# Altium schematic sheet [10] - DIGITAL INPUT.SchDoc("[10] - DIGITAL INPUT")
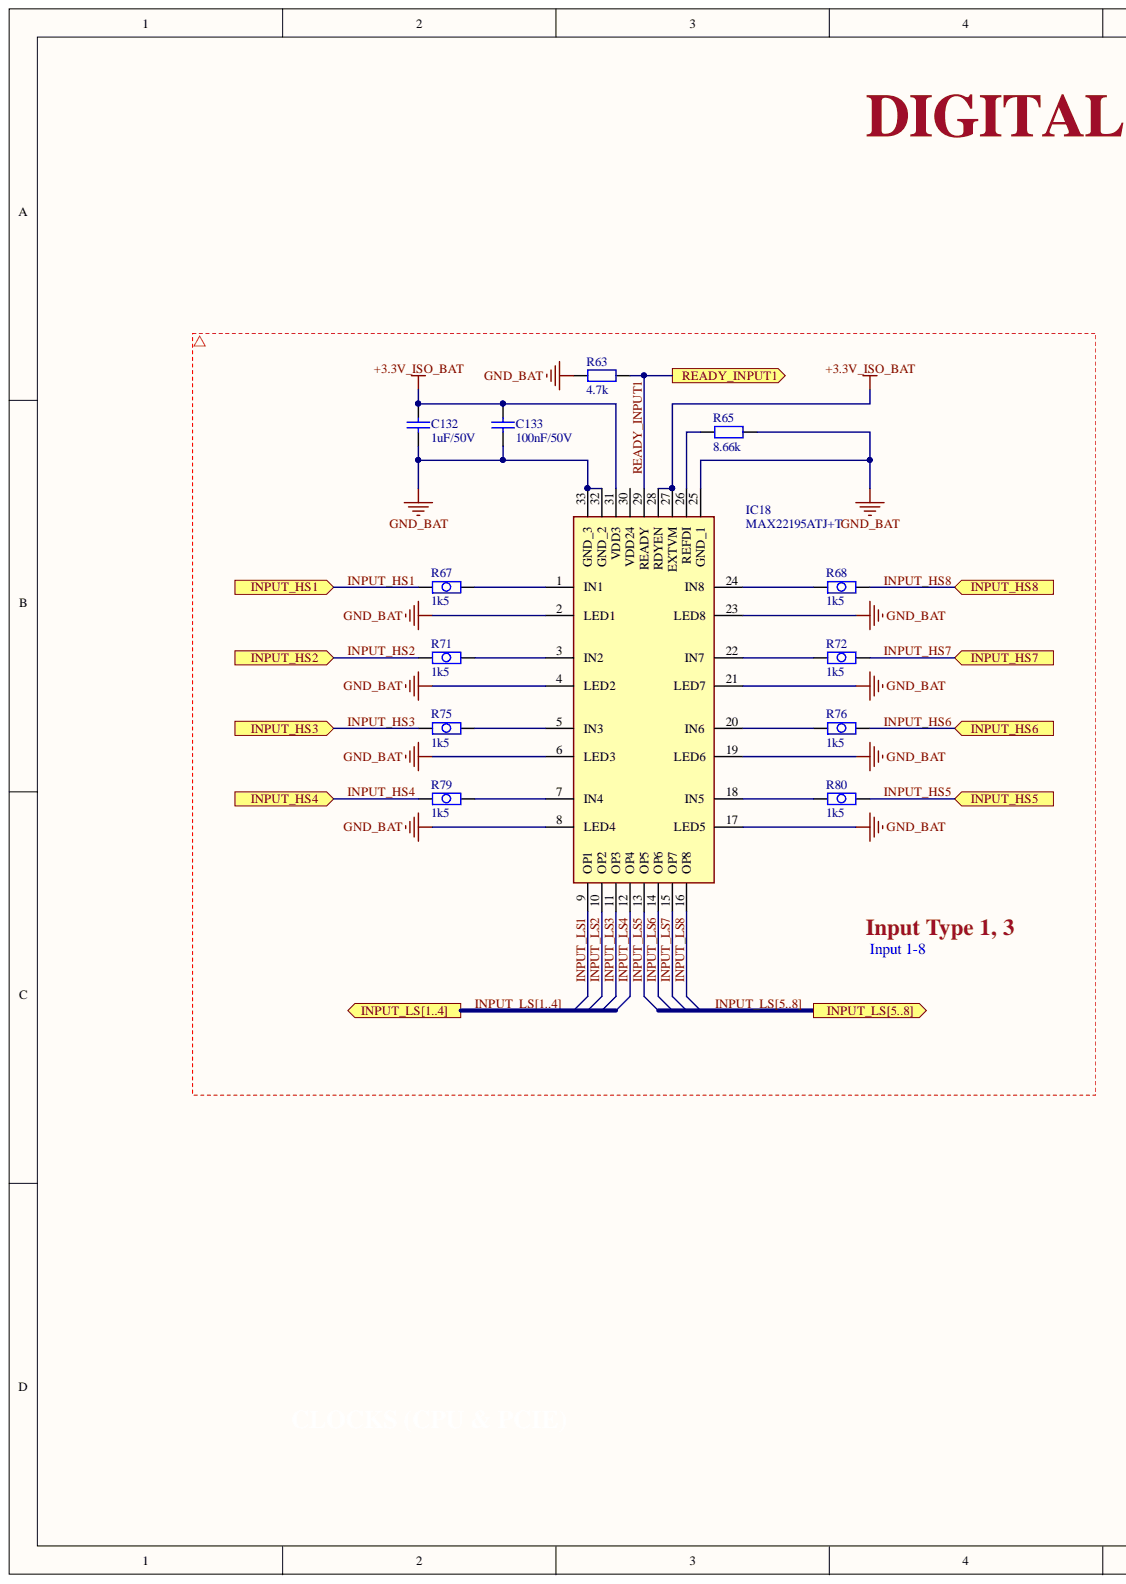
[diagram p0: [10] - DIGITAL INPUT.SchDoc, left]
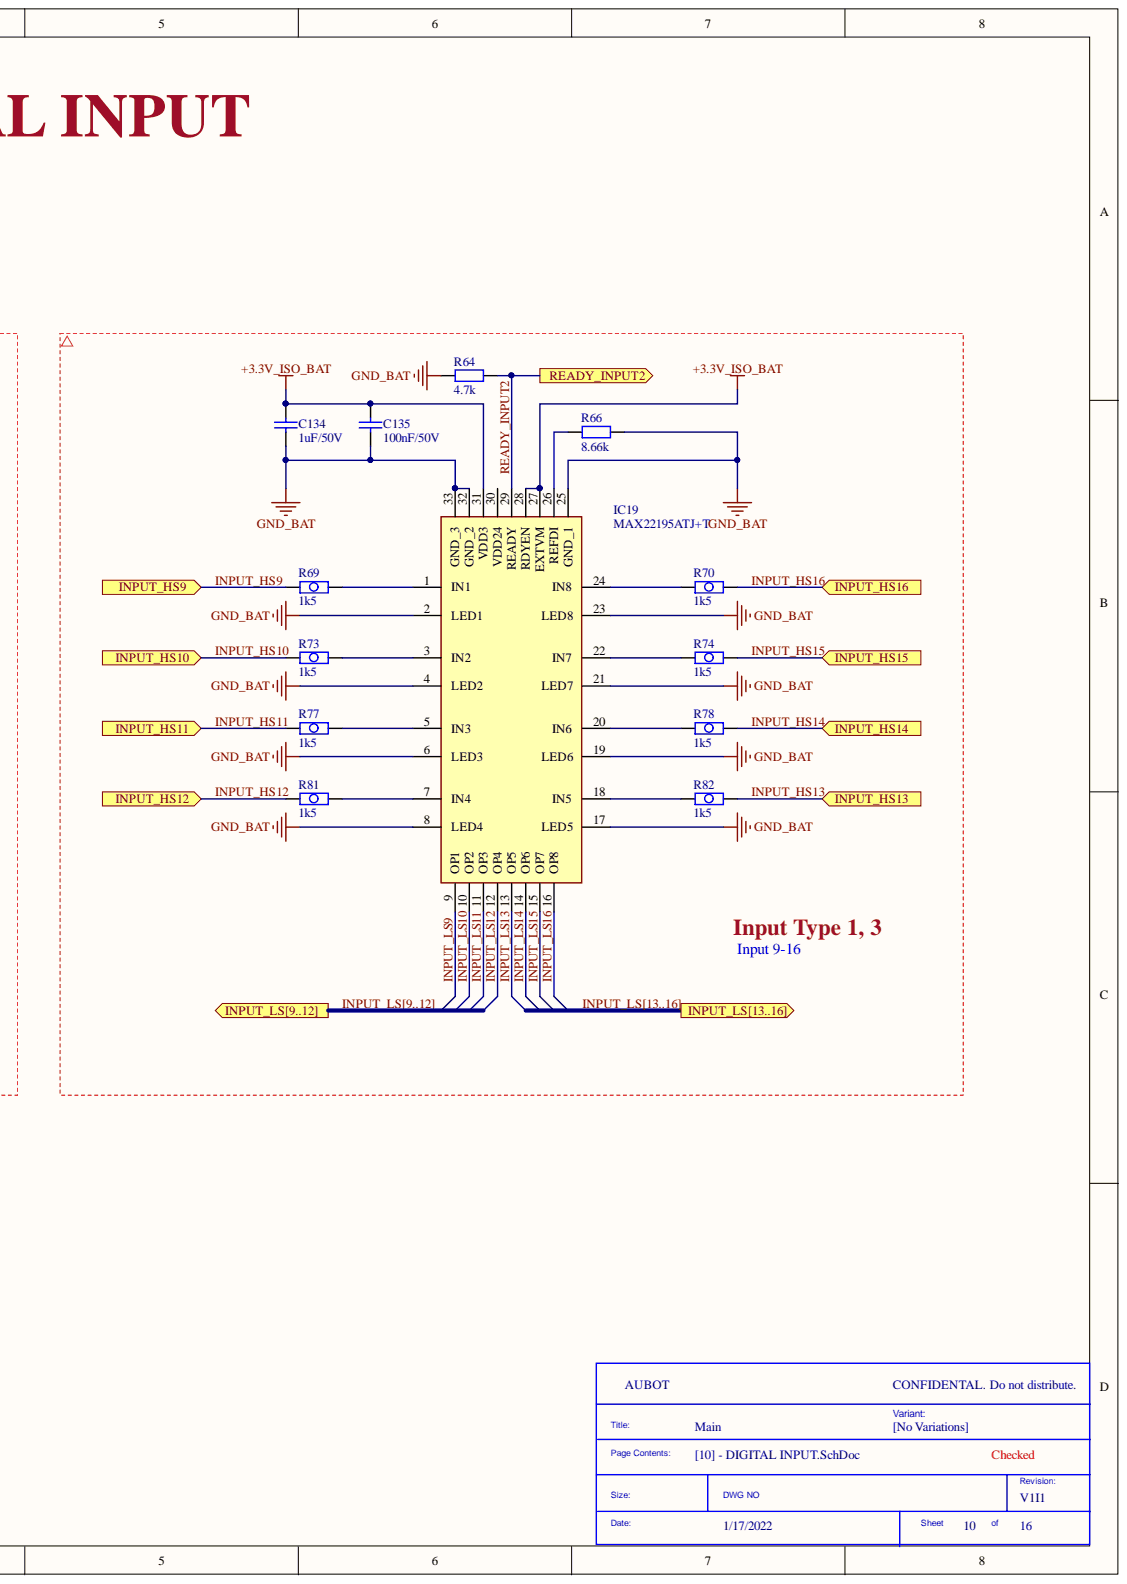
[diagram p1: [10] - DIGITAL INPUT.SchDoc, right]

## Parts
C132  1uF/50V  [CAP 0805]
C133  100nF/50V  [CAP 0805]
C134  1uF/50V  [CAP 0805]
C135  100nF/50V  [CAP 0805]
IC18  MAX22195ATJ+T  [32-WFQFN Exposed Pad]
IC19  MAX22195ATJ+T  [32-WFQFN Exposed Pad]
R63  4.7k  [RES 0805]
R64  4.7k  [RES 0805]
R65  8.66k  [RES 0805]
R66  8.66k  [RES 0805]
R67  1k5  [CMB 0207]
R68  1k5  [CMB 0207]
R69  1k5  [CMB 0207]
R70  1k5  [CMB 0207]
R71  1k5  [CMB 0207]
R72  1k5  [CMB 0207]
R73  1k5  [CMB 0207]
R74  1k5  [CMB 0207]
R75  1k5  [CMB 0207]
R76  1k5  [CMB 0207]
R77  1k5  [CMB 0207]
R78  1k5  [CMB 0207]
R79  1k5  [CMB 0207]
R80  1k5  [CMB 0207]
R81  1k5  [CMB 0207]
R82  1k5  [CMB 0207]

## Ports
INPUT_HS1, INPUT_HS2, INPUT_HS3, INPUT_HS4, INPUT_HS5, INPUT_HS6, INPUT_HS7, INPUT_HS8, INPUT_HS9, INPUT_HS10, INPUT_HS11, INPUT_HS12, INPUT_HS13, INPUT_HS14, INPUT_HS15, INPUT_HS16, INPUT_LS1, INPUT_LS2, INPUT_LS3, INPUT_LS4, INPUT_LS5, INPUT_LS6, INPUT_LS7, INPUT_LS8, INPUT_LS9, INPUT_LS10, INPUT_LS11, INPUT_LS12, INPUT_LS13, INPUT_LS14, INPUT_LS15, INPUT_LS16, INPUT_LS[1..4], INPUT_LS[5..8], INPUT_LS[9..12], INPUT_LS[13..16], READY_INPUT1, READY_INPUT2

## Nets
+3.3V_ISO_BAT: C132.2 C133.2 C134.2 C135.2 IC18.27(EXTVM) IC18.28(RDYEN) IC18.31(VDD3) IC19.27(EXTVM) IC19.28(RDYEN) IC19.31(VDD3)
GND_BAT: C132.1 C133.1 C134.1 C135.1 IC18.2(LED1) IC18.4(LED2) IC18.6(LED3) IC18.8(LED4) IC18.17(LED5) IC18.19(LED6) IC18.21(LED7) IC18.23(LED8) IC18.25(GND_1) IC18.32(GND_2) IC18.33(GND_3) IC19.2(LED1) IC19.4(LED2) IC19.6(LED3) IC19.8(LED4) IC19.17(LED5) IC19.19(LED6) IC19.21(LED7) IC19.23(LED8) IC19.25(GND_1) IC19.32(GND_2) IC19.33(GND_3) R63.1 R64.1 R65.2 R66.2
INPUT_HS1: R67.1 ; port INPUT_HS1
INPUT_HS2: R71.1 ; port INPUT_HS2
INPUT_HS3: R75.1 ; port INPUT_HS3
INPUT_HS4: R79.1 ; port INPUT_HS4
INPUT_HS5: R80.2 ; port INPUT_HS5
INPUT_HS6: R76.2 ; port INPUT_HS6
INPUT_HS7: R72.2 ; port INPUT_HS7
INPUT_HS8: R68.2 ; port INPUT_HS8
INPUT_HS9: R69.1 ; port INPUT_HS9
INPUT_HS10: R73.1 ; port INPUT_HS10
INPUT_HS11: R77.1 ; port INPUT_HS11
INPUT_HS12: R81.1 ; port INPUT_HS12
INPUT_HS13: R82.2 ; port INPUT_HS13
INPUT_HS14: R78.2 ; port INPUT_HS14
INPUT_HS15: R74.2 ; port INPUT_HS15
INPUT_HS16: R70.2 ; port INPUT_HS16
INPUT_LS1: IC18.9(OP1) ; port INPUT_LS[1..4]  [also labelled INPUT_LS]
INPUT_LS2: IC18.10(OP2) ; port INPUT_LS[1..4]  [also labelled INPUT_LS]
INPUT_LS3: IC18.11(OP3) ; port INPUT_LS[1..4]  [also labelled INPUT_LS]
INPUT_LS4: IC18.12(OP4) ; port INPUT_LS[1..4]  [also labelled INPUT_LS]
INPUT_LS5: IC18.13(OP5) ; port INPUT_LS[5..8]  [also labelled INPUT_LS]
INPUT_LS6: IC18.14(OP6) ; port INPUT_LS[5..8]  [also labelled INPUT_LS]
INPUT_LS7: IC18.15(OP7) ; port INPUT_LS[5..8]  [also labelled INPUT_LS]
INPUT_LS8: IC18.16(OP8) ; port INPUT_LS[5..8]  [also labelled INPUT_LS]
INPUT_LS9: IC19.9(OP1) ; port INPUT_LS[9..12]  [also labelled INPUT_LS]
INPUT_LS10: IC19.10(OP2) ; port INPUT_LS[9..12]  [also labelled INPUT_LS]
INPUT_LS11: IC19.11(OP3) ; port INPUT_LS[9..12]  [also labelled INPUT_LS]
INPUT_LS12: IC19.12(OP4) ; port INPUT_LS[9..12]  [also labelled INPUT_LS]
INPUT_LS13: IC19.13(OP5) ; port INPUT_LS[13..16]  [also labelled INPUT_LS]
INPUT_LS14: IC19.14(OP6) ; port INPUT_LS[13..16]  [also labelled INPUT_LS]
INPUT_LS15: IC19.15(OP7) ; port INPUT_LS[13..16]  [also labelled INPUT_LS]
INPUT_LS16: IC19.16(OP8) ; port INPUT_LS[13..16]  [also labelled INPUT_LS]
READY_INPUT1: IC18.29(READY) R63.2 ; port READY_INPUT1
READY_INPUT2: IC19.29(READY) R64.2 ; port READY_INPUT2
NetIC18_1: IC18.1(IN1) R67.2
NetIC18_3: IC18.3(IN2) R71.2
NetIC18_5: IC18.5(IN3) R75.2
NetIC18_7: IC18.7(IN4) R79.2
NetIC18_18: IC18.18(IN5) R80.1
NetIC18_20: IC18.20(IN6) R76.1
NetIC18_22: IC18.22(IN7) R72.1
NetIC18_24: IC18.24(IN8) R68.1
NetIC18_26: IC18.26(REFDI) R65.1
NetIC19_1: IC19.1(IN1) R69.2
NetIC19_3: IC19.3(IN2) R73.2
NetIC19_5: IC19.5(IN3) R77.2
NetIC19_7: IC19.7(IN4) R81.2
NetIC19_18: IC19.18(IN5) R82.1
NetIC19_20: IC19.20(IN6) R78.1
NetIC19_22: IC19.22(IN7) R74.1
NetIC19_24: IC19.24(IN8) R70.1
NetIC19_26: IC19.26(REFDI) R66.1

Not connected: IC18 30(VDD24); IC19 30(VDD24)

## Library data of the parts
C132 C134 = Cap 0805 1uF/50V: 0805 1 uF 50 V ±10 % Tolerance X7R SMT Multilayer Ceramic Capacitor; Manufacturer Part Number: CC0805KKX7R9BB105; Manufacturer: Yageo; Voltage Rating (DC): 50V; Tolerance: 10%; Capacitance: 1uF; Width: 0.049inch; Weight: 0.000194oz; Voltage Rating: 50V; Thickness: 0.057inch; Termination: SMD/SMT; Supplier Part Number 1: 311-1886-1-ND; Supplier 1: Digi-Key; Stock 1: 174238; Series: CC; RoHS Compliant: Yes; RoHS: Yes; Resistor Type: Ceramic; Radiation Hardening: No; Pricing 1: 1 = 0.26000, 10 = 0.17900, 50 = 0.11920, 100 = 0.10130, 500 = 0.07152, 1000 = 0.06257 (USD); Packaging: Tape and Reel; Mount: Surface Mount; Min Operating Temperature: -55°C; Max Operating Temperature: 125°C; Length: 2mm; Lead Free: Lead Free; Height: 1.25mm; Halogen Free: Halogen Free; Dielectric: X7R; Depth: 1.25mm; Construction: Flat; ComponentLink1URL: https://datasheet.ciiva.com/13209/upy-gphc-x7r-6-3v-to-50v-8-12304-13209375.pdf?src-supplier=Mouser; ComponentLink1Description: https://datasheet.ciiva.com/13209/upy-gphc-x7r-6-3v-to-50v-8-12304-13209375.pdf?src-supplier=Mouser; Component Kind: Standard; Case Code (Metric): 2012; Case Code (Imperial): 0805; Case/Package: 0805; Library Name: Hieu_Lib.IntLib
C133 C135 = Cap 0805 100nF/50V: Ceramic capacitor SMD-0805 100nF /50V X7R# K +-10%, Samsung, RoHS; Manufacturer Part Number: CL21B104KBCNNNC; Voltage Rating (DC): 50V; Tolerance: 10%; Dielectric: X7R; Width: 0.049inch; Voltage Rating: 50V; Voltage: 50V; Thickness: 0.037inch; Supplier Part Number 1: C1711; Supplier 1: LCSC; Stock 1: 447650; Series: CL; RoHS Compliant: Yes; RoHS: Yes; Radiation Hardening: No; Pricing 1: 50 = 0.04090, 500 = 0.03130, 1500 = 0.02890, 5000 = 0.02580, 25000 = 0.02430, 50000 = 0.02410, 50 = 0.03950, 500 = 0.03020, 1500 = 0.02790, 5000 = 0.02490, 25000 = 0.02350, 50000 = 0.02330, 50 = 0.20680, 500 = 0.15820, 1500 = 0.14600, 5000 = 0.13020, 25000 = 0.12290, 50000 = 0.12170, 50 = 0.19640, 500 = 0.15020, 1500 = 0.13860, 5000 = 0.12360, 25000 = 0.11670, 50000 = 0.11550, 50 = 0.02640, 500 = 0.02020, 1500 = 0.01860, 5000 = 0.01660, 25000 = 0.01570, 50000 = 0.01550, 50 = 0.02300, 500 = 0.01760, 1500 = 0.01620, 5000 = 0.01440, 25000 = 0.01360, 50000 = 0.01350, 50 = 0.24740, 500 = 0.18920, 1500 = 0.17470, 5000 = 0.15570, 25000 = 0.14700, 50000 = 0.14560, 50 = 3.45220, 500 = 2.64000, 1500 = 2.43700, 5000 = 2.17300, 25000 = 2.05110, 50000 = 2.03080, 50 = 0.13070, 500 = 0.09990, 1500 = 0.09220, 5000 = 0.08230, 25000 = 0.07760, 50000 = 0.07690, 50 = 2.39050, 500 = 1.82810, 1500 = 1.68750, 5000 = 1.50470, 25000 = 1.42030, 50000 = 1.40620, 50 = 0.26740, 500 = 0.20450, 1500 = 0.18870, 5000 = 0.16830, 25000 = 0.15890, 50000 = 0.15730, 50 = 0.04230, 500 = 0.03230, 1500 = 0.02990, 5000 = 0.02660, 25000 = 0.02510, 50000 = 0.02490, 50 = 0.03188, 500 = 0.02438, 1500 = 0.02251, 5000 = 0.02007, 25000 = 0.01894, 50000 = 0.01875 (AUD); Packaging: Tape and Reel; Mount: Surface Mount; Min Operating Temperature: -55°C; Max Operating Temperature: 125°C; Material: Ceramic; Manufacturer: Samsung; Length: 2mm; Lead Free: Lead Free; Height: 0.85mm; Depth: 1.25mm; Contact Plating: Tin; Construction: Flat; ComponentLink2URL: https://datasheet.ciiva.com/29199/454152-da-01-en-vs-kondensator-0805-29199814.pdf?src-supplier=Conrad; ComponentLink2Description: https://datasheet.ciiva.com/29199/454152-da-01-en-vs-kondensator-0805-29199814.pdf?src-supplier=Conrad; ComponentLink1URL: https://datasheet.ciiva.com/25710/cl21b104kbcnnnc-25710182.pdf?src-supplier=Digi-Key; ComponentLink1Description: https://datasheet.ciiva.com/25710/cl21b104kbcnnnc-25710182.pdf?src-supplier=Digi-Key; Component Kind: Standard; Case Code (Metric): 2012; Case Code (Imperial): 0805; Case/Package: 0805; Capacitance: 100nF; Library Name: Hieu_Lib.IntLib
IC18 IC19 = MAX22195ATJ+T: Industry's Smallest, Robust, Octal Digital Input with Parallel Output; Manufacturer: Maxim; Supplier Part Number 1: MAX22195ATJ+T-ND; Supplier 1: Digi-Key; Stock 1: 0; RoHS: No; Pricing 1: 2500 = 3.24000 (USD); Manufacturer Part Number: MAX22195ATJ+T; ComponentLink1URL: https://datasheets.maximintegrated.com/en/ds/MAX22195.pdf?src-supplier=Digi-Key; ComponentLink1Description: https://datasheets.maximintegrated.com/en/ds/MAX22195.pdf?src-supplier=Digi-Key; Component Kind: Standard; Library Name: Hieu_Lib.SchLib
R63 R64 = Res 0805 4.7k Ohm 1%: General Purpose Chip Resistor Thick Film 0805 4.7kOhm 1% Paper T/R; Manufacturer Part Number: RC0805FR-074K7L; Manufacturer: Yageo; Voltage Rating (DC): 150V; Temperature Coefficient: 100ppm/°C; Resistance: 4.7kR; Power Rating: 125mW; Width: 0.049inch; Voltage Rating: 150V; Tolerance: 1%; Termination: SMD/SMT; Technology: THICK FILM; Supplier Part Number 1: 311-4.70KCRCT-ND; Supplier 1: Digi-Key; Stock 1: 159494; Size Code: 0805; Series: RC; RoHS Compliant: Yes; RoHS: Yes; Resistor Type: Thick Film; Resistance Tolerance: 1%; REACH SVHC: No SVHC; Pricing 1: 1 = 0.10000, 10 = 0.04200, 100 = 0.01690, 1000 = 0.00759, 2500 = 0.00659 (USD); Packaging: Tape and Reel; Package Quantity: 5000; Number of Terminations: 2; Number of Pins: 2; Min Operating Temperature: -55°C; Military Standard: Not; Max Power Dissipation: 125mW; Max Operating Temperature: 155°C; Length: 0.079inch; Lead Free: Lead Free; Height: 0.024inch; Features: Moisture Resistant; Depth: 1.25mm; Construction: Rectangular; ComponentLink6URL: https://datasheet.ciiva.com/46447/444164-46447583.pdf?src-supplier=Element14; ComponentLink6Description: https://datasheet.ciiva.com/46447/444164-46447583.pdf?src-supplier=Element14; ComponentLink5URL: https://datasheet.ciiva.com/6547/pyu-r-int-thick-7-6547263.pdf?src-supplier=Digi-Key; ComponentLink5Description: https://datasheet.ciiva.com/6547/pyu-r-int-thick-7-6547263.pdf?src-supplier=Digi-Key; ComponentLink4URL: https://datasheet.ciiva.com/7941/444164-7941806.pdf?src-supplier=Element14; ComponentLink4Description: https://datasheet.ciiva.com/7941/444164-7941806.pdf?src-supplier=Element14; ComponentLink3URL: https://datasheet.ciiva.com/7941/1686128-7941805.pdf?src-supplier=Element14; ComponentLink3Description: https://datasheet.ciiva.com/7941/1686128-7941805.pdf?src-supplier=Element14; ComponentLink2URL: https://datasheet.ciiva.com/7941/444164-7941804.pdf?src-supplier=Element14; ComponentLink2Description: https://datasheet.ciiva.com/7941/444164-7941804.pdf?src-supplier=Element14; ComponentLink1URL: https://datasheet.ciiva.com/11808/0900766b8106beab-11808155.pdf?src-supplier=RSComponents; ComponentLink1Description: https://datasheet.ciiva.com/11808/0900766b8106beab-11808155.pdf?src-supplier=RSComponents; Component Kind: Standard; Case Code (Metric): 2012; Case Code (Imperial): 0805; Case/Package: 0805; Library Name: Hieu_Lib.SchLib
R65 R66 = Res 0805 8.66k Ohm 1%: Res Thick Film 2.0 x 1.2 mm 8.66K Ohm 1% 0.125W(1/8W) 100ppm/ C Molded SMD Paper T/R; Manufacturer Part Number: RC0805FR-078K66L; Manufacturer: Yageo; Voltage Rating (DC): 150V; Temperature Coefficient: 100ppm/°C; Resistance: 8.66kR; Power Rating: 125mW; Width: 0.049inch; Voltage Rating: 150V; Tolerance: 1%; Termination: SMD/SMT; Supplier Part Number 1: 311-8.66KCRCT-ND; Supplier 1: Digi-Key; Stock 1: 34650; Size Code: 0805; Series: RC; RoHS Compliant: Yes; RoHS: Yes; Resistor Type: Thick Film; Radiation Hardening: No; Pricing 1: 1 = 0.10000, 10 = 0.04200, 100 = 0.01690, 1000 = 0.00759, 2500 = 0.00659 (USD); Packaging: Tape and Reel; Package Quantity: 1; Number of Terminations: 2; Min Operating Temperature: -55°C; Military Standard: Not; Max Power Dissipation: 125mW; Max Operating Temperature: 155°C; Length: 2mm; Lead Free: Lead Free; Height: 0.024inch; Features: Moisture Resistant; Depth: 1.25mm; Contact Plating: Tin,Lead,Matte; Construction: Rectangular; ComponentLink4URL: https://datasheet.ciiva.com/15190/getdatasheetpartid-553176-15190021.pdf?src-supplier=Verical; ComponentLink4Description: https://datasheet.ciiva.com/15190/getdatasheetpartid-553176-15190021.pdf?src-supplier=Verical; ComponentLink3URL: https://datasheet.ciiva.com/2458/pyu-r-int-thick-7-2458036.pdf?src-supplier=Digi-Key; ComponentLink3Description: https://datasheet.ciiva.com/2458/pyu-r-int-thick-7-2458036.pdf?src-supplier=Digi-Key; ComponentLink2URL: https://datasheet.ciiva.com/2458/pyu-r-int-thick-7-2458035.pdf?src-supplier=Digi-Key; ComponentLink2Description: https://datasheet.ciiva.com/2458/pyu-r-int-thick-7-2458035.pdf?src-supplier=Digi-Key; ComponentLink1URL: https://datasheet.ciiva.com/2458/pyu-r-int-thick-7-2458034.pdf?src-supplier=Digi-Key; ComponentLink1Description: https://datasheet.ciiva.com/2458/pyu-r-int-thick-7-2458034.pdf?src-supplier=Digi-Key; Component Kind: Standard; Case Code (Metric): 2012; Case Code (Imperial): 0805; Case/Package: 0805; Library Name: Hieu_Lib.IntLib
R67-R82 = Res pulse 1k5 Ohm CMB02070X1501GB200: Res Carbon Film 0207(2309) 1.5K Ohm 2% 2/5W Conformal Melf SMD Blister T/R; Manufacturer: Vishay Beyschlag; Voltage Rating (DC): 500V; Temperature Coefficient: -250ppm/°C; Resistance: 1.5kR; Power Rating: 400mW; Weight: 0.002787oz; Voltage Rating: 500V; Tolerance: 2%; Termination: SMD/SMT; Technology: CARBON FILM; Supplier Part Number 1: 594-CMB02070X1501GB2; Supplier 1: Mouser; Stock 1: 8827; Series: CMB 0207; RoHS Compliant: Yes; RoHS: Yes; Resistor Type: Carbon Film; Resistance Tolerance: 2%; REACH SVHC: Unknown; Radiation Hardening: No; Pricing 1: 1 = 0.63000, 10 = 0.40500, 50 = 0.40500, 100 = 0.35300, 1000 = 0.20700, 10000 = 0.19900 (USD); Packaging: Tape and Reel; Package Quantity: 2000; Operating Supply Voltage: 500V; Min Operating Temperature: -55°C; Military Standard: Not; Max Operating Temperature: 125°C; Manufacturer Part Number: CMB02070X1501GB200; Length: 5.8mm; Lead Free: Lead Free; Diameter: 5.8mm; Contact Plating: Tin; Construction: Cylindrical; ComponentLink6URL: https://datasheet.ciiva.com/16887/cmb0207-16887309.pdf?src-supplier=Avnet+Europe; ComponentLink6Description: https://datasheet.ciiva.com/16887/cmb0207-16887309.pdf?src-supplier=Avnet+Europe; ComponentLink5URL: https://datasheet.ciiva.com/8896/cmb0207-8896890.pdf?src-supplier=Avnet; ComponentLink5Description: https://datasheet.ciiva.com/8896/cmb0207-8896890.pdf?src-supplier=Avnet; ComponentLink4URL: https://datasheet.ciiva.com/7803/360009-7803098.pdf?src-supplier=Element14; ComponentLink4Description: https://datasheet.ciiva.com/7803/360009-7803098.pdf?src-supplier=Element14; ComponentLink3URL: https://datasheet.ciiva.com/7803/360009-7803097.pdf?src-supplier=Element14; ComponentLink3Description: https://datasheet.ciiva.com/7803/360009-7803097.pdf?src-supplier=Element14; ComponentLink2URL: https://datasheet.ciiva.com/11817/0900766b80d9877b-11817556.pdf?src-supplier=RSComponents; ComponentLink2Description: https://datasheet.ciiva.com/11817/0900766b80d9877b-11817556.pdf?src-supplier=RSComponents; ComponentLink1URL: https://datasheet.ciiva.com/12364/cmb0207-72917-12364384.pdf?src-supplier=Mouser; ComponentLink1Description: https://datasheet.ciiva.com/12364/cmb0207-72917-12364384.pdf?src-supplier=Mouser; Component Kind: Standard; Case/Package: Conformal; Library Name: Hieu_Lib.IntLib
